# Altium schematic sheet Sitara_IO_Bank_45.SchDoc
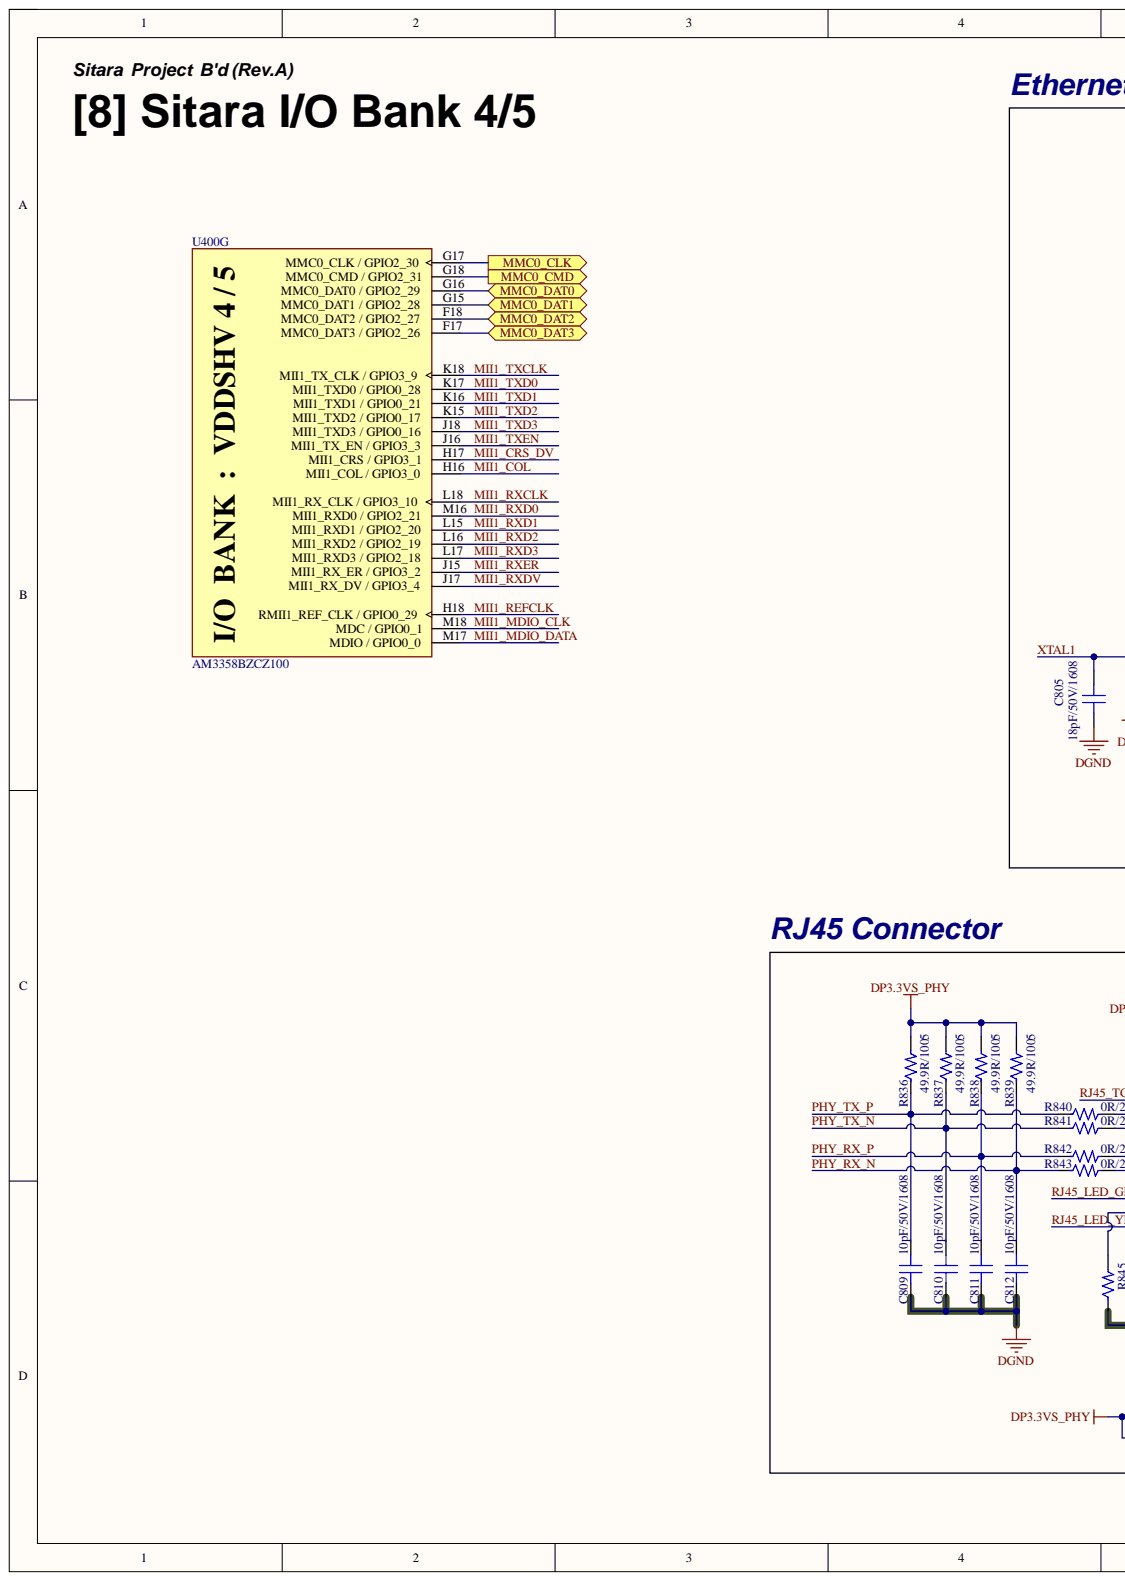
[diagram p0: Sitara_IO_Bank_45.SchDoc, left]
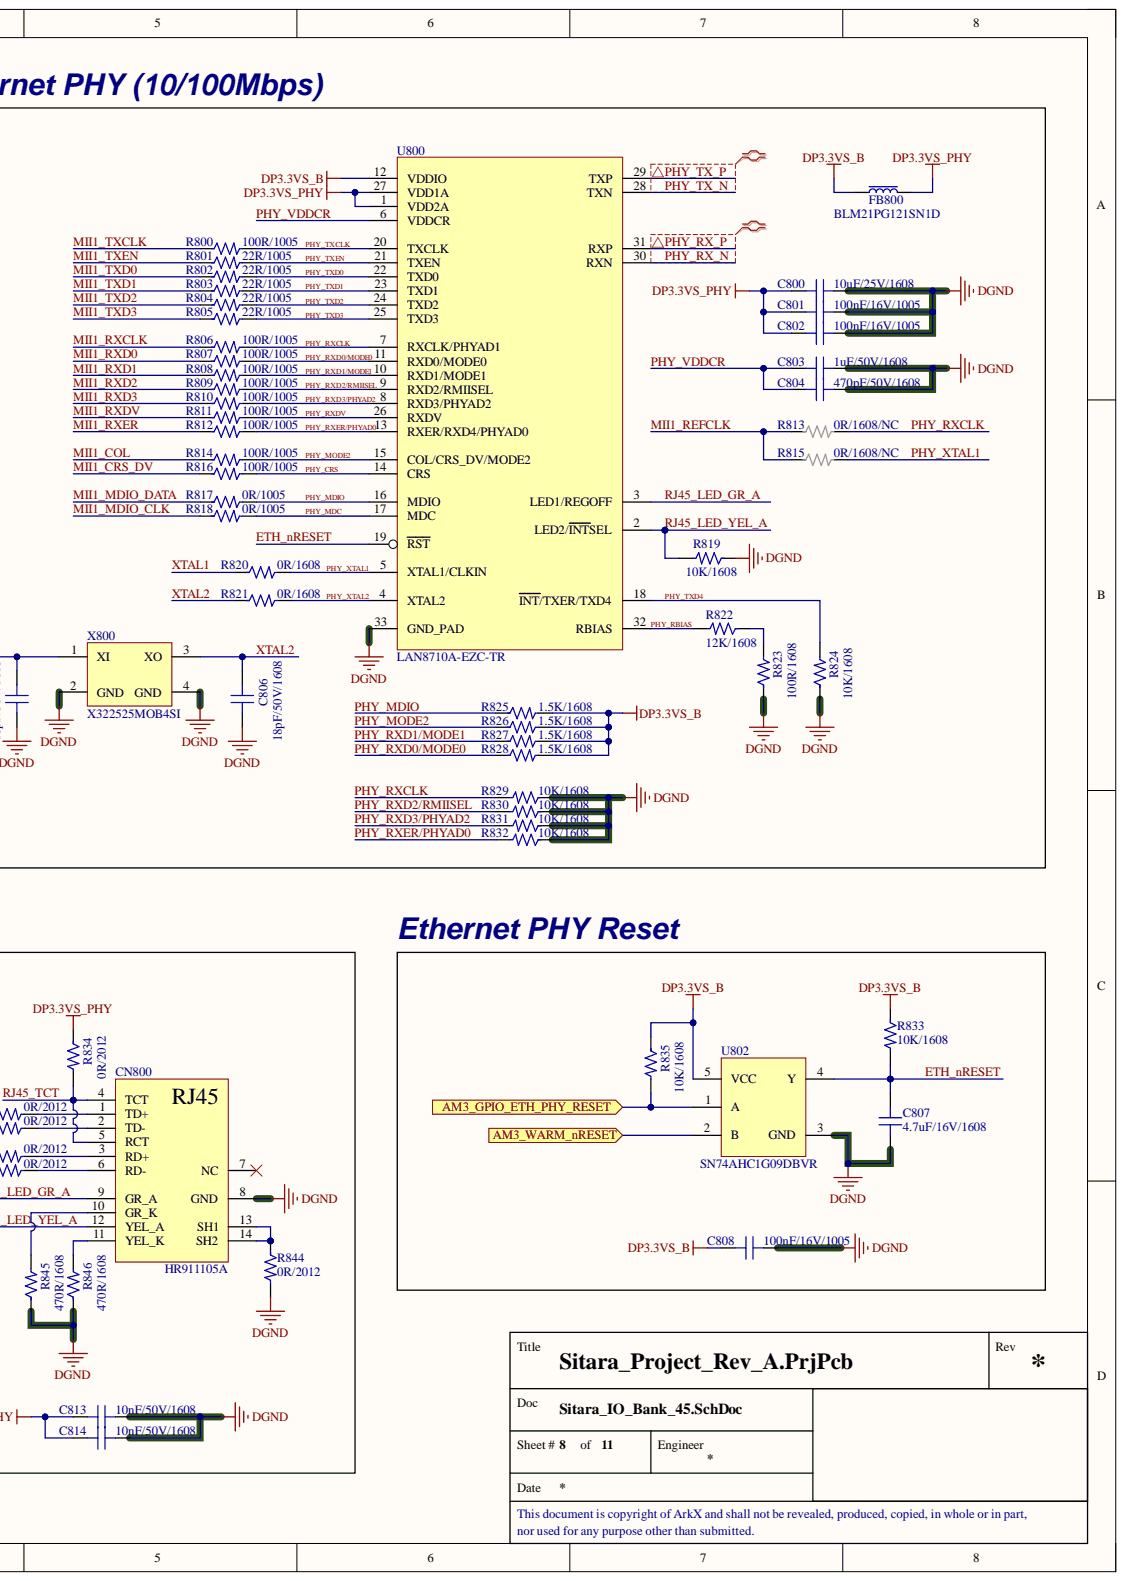
[diagram p1: Sitara_IO_Bank_45.SchDoc, right]

## Parts
C800  10uF/25V/1608  [CAP 1608 (0603_INCH)]
C801  100nF/16V/1005  [CAP 1005 (0402_INCH)]
C802  100nF/16V/1005  [CAP 1005 (0402_INCH)]
C803  1uF/50V/1608  [CAP 1608 (0603_INCH)]
C804  470pF/50V/1608  [CAP 1608 (0603_INCH)]
C805  18pF/50V/1608  [CAP 1608 (0603_INCH)]
C806  18pF/50V/1608  [CAP 1608 (0603_INCH)]
C807  4.7uF/16V/1608  [CAP 1608 (0603_INCH)]
C808  100nF/16V/1005  [CAP 1005 (0402_INCH)]
C809  10pF/50V/1608  [CAP 1608 (0603_INCH)]
C810  10pF/50V/1608  [CAP 1608 (0603_INCH)]
C811  10pF/50V/1608  [CAP 1608 (0603_INCH)]
C812  10pF/50V/1608  [CAP 1608 (0603_INCH)]
C813  10nF/50V/1608  [CAP 1608 (0603_INCH)]
C814  10nF/50V/1608  [CAP 1608 (0603_INCH)]
CN800  HR911105A  [CON_RJ45]
FB800  BLM21PG121SN1D  [FB 2012 (0805_inch)]
R800  100R/1005  [RES 1005 (0402_inch)]
R801  22R/1005  [RES 1005 (0402_inch)]
R802  22R/1005  [RES 1005 (0402_inch)]
R803  22R/1005  [RES 1005 (0402_inch)]
R804  22R/1005  [RES 1005 (0402_inch)]
R805  22R/1005  [RES 1005 (0402_inch)]
R806  100R/1005  [RES 1005 (0402_inch)]
R807  100R/1005  [RES 1005 (0402_inch)]
R808  100R/1005  [RES 1005 (0402_inch)]
R809  100R/1005  [RES 1005 (0402_inch)]
R810  100R/1005  [RES 1005 (0402_inch)]
R811  100R/1005  [RES 1005 (0402_inch)]
R812  100R/1005  [RES 1005 (0402_inch)]
R813  0R/1608/NC  [RES 1608 (0603_inch)/NC]
R814  100R/1005  [RES 1005 (0402_inch)]
R815  0R/1608/NC  [RES 1608 (0603_inch)/NC]
R816  100R/1005  [RES 1005 (0402_inch)]
R817  0R/1005  [RES 1005 (0402_inch)]
R818  0R/1005  [RES 1005 (0402_inch)]
R819  10K/1608  [RES 1608 (0603_inch)]
R820  0R/1608  [RES 1608 (0603_inch)]
R821  0R/1608  [RES 1608 (0603_inch)]
R822  12K/1608  [RES 1608 (0603_inch)]
R823  100R/1608  [RES 1608 (0603_inch)]
R824  10K/1608  [RES 1608 (0603_inch)]
R825  1.5K/1608  [RES 1608 (0603_inch)]
R826  1.5K/1608  [RES 1608 (0603_inch)]
R827  1.5K/1608  [RES 1608 (0603_inch)]
R828  1.5K/1608  [RES 1608 (0603_inch)]
R829  10K/1608  [RES 1608 (0603_inch)]
R830  10K/1608  [RES 1608 (0603_inch)]
R831  10K/1608  [RES 1608 (0603_inch)]
R832  10K/1608  [RES 1608 (0603_inch)]
R833  10K/1608  [RES 1608 (0603_inch)]
R834  0R/2012  [RES 2012 (0805_inch)]
R835  10K/1608  [RES 1608 (0603_inch)]
R836  49.9R/1005  [RES 1005 (0402_inch)]
R837  49.9R/1005  [RES 1005 (0402_inch)]
R838  49.9R/1005  [RES 1005 (0402_inch)]
R839  49.9R/1005  [RES 1005 (0402_inch)]
R840  0R/2012  [RES 2012 (0805_inch)]
R841  0R/2012  [RES 2012 (0805_inch)]
R842  0R/2012  [RES 2012 (0805_inch)]
R843  0R/2012  [RES 2012 (0805_inch)]
R844  0R/2012  [RES 2012 (0805_inch)]
R845  470R/1608  [RES 1608 (0603_inch)]
R846  470R/1608  [RES 1608 (0603_inch)]
U400  AM3358BZCZ100  [IC_SOC_AM3358BZCZ100]  (part G)
U800  LAN8710A-EZC-TR  [IC_QFN-32 (5x5)]
U802  SN74AHC1G09DBVR  [SOT-23-5 (TI DBV PACKAGE)]
X800  X322525MOB4SI  [OSC_SMD_3.2x2.5(YXC)]

## Ports
AM3_GPIO_ETH_PHY_RESET, AM3_WARM_nRESET, MMC0_CLK, MMC0_CMD, MMC0_DAT0, MMC0_DAT1, MMC0_DAT2, MMC0_DAT3

## Nets
DGND: C800.2 C801.2 C802.2 C803.2 C804.2 C805.1 C806.1 C807.1 C808.2 C809.1 C810.1 C811.1 C812.1 C813.2 C814.2 CN800.8(GND) R819.1 R823.1 R824.1 R829.1 R830.1 R831.1 R832.1 R844.2 R845.1 R846.1 U800.33(GND_PAD) U802.3(GND) X800.2(GND) X800.4(GND)
DP3.3VS_B: C808.1 FB800.1 R825.2 R826.2 R827.2 R828.2 R833.1 R835.1 U800.12(VDDIO) U802.5(VCC)
DP3.3VS_PHY: C800.1 C801.1 C802.1 C813.1 C814.1 FB800.2 R834.1 R836.2 R837.2 R838.2 R839.2 U800.1(VDD2A) U800.27(VDD1A)
AM3_GPIO_ETH_PHY_RESET: R835.2 U802.1(A) ; port AM3_GPIO_ETH_PHY_RESET
AM3_WARM_nRESET: U802.2(B) ; port AM3_WARM_nRESET
ETH_nRESET: C807.2 R833.2 U800.19(RST) U802.4(Y)
MII1_COL: R814.1 U400.H16(MII1_COL_/_GPIO3_0)
MII1_CRS_DV: R816.1 U400.H17(MII1_CRS_/_GPIO3_1)
MII1_MDIO_CLK: R818.1 U400.M18(MDC_/_GPIO0_1)
MII1_MDIO_DATA: R817.1 U400.M17(MDIO_/_GPIO0_0)
MII1_REFCLK: R813.1 R815.1 U400.H18(RMII1_REF_CLK_/_GPIO0_29)
MII1_RXCLK: R806.1 U400.L18(MII1_RX_CLK_/_GPIO3_10)
MII1_RXD0: R807.1 U400.M16(MII1_RXD0_/_GPIO2_21)
MII1_RXD1: R808.1 U400.L15(MII1_RXD1_/_GPIO2_20)
MII1_RXD2: R809.1 U400.L16(MII1_RXD2_/_GPIO2_19)
MII1_RXD3: R810.1 U400.L17(MII1_RXD3_/_GPIO2_18)
MII1_RXDV: R811.1 U400.J17(MII1_RX_DV_/_GPIO3_4)
MII1_RXER: R812.1 U400.J15(MII1_RX_ER_/_GPIO3_2)
MII1_TXCLK: R800.1 U400.K18(MII1_TX_CLK_/_GPIO3_9)
MII1_TXD0: R802.1 U400.K17(MII1_TXD0_/_GPIO0_28)
MII1_TXD1: R803.1 U400.K16(MII1_TXD1_/_GPIO0_21)
MII1_TXD2: R804.1 U400.K15(MII1_TXD2_/_GPIO0_17)
MII1_TXD3: R805.1 U400.J18(MII1_TXD3_/_GPIO0_16)
MII1_TXEN: R801.1 U400.J16(MII1_TX_EN_/_GPIO3_3)
MMC0_CLK: U400.G17(MMC0_CLK_/_GPIO2_30) ; port MMC0_CLK
MMC0_CMD: U400.G18(MMC0_CMD_/_GPIO2_31) ; port MMC0_CMD
MMC0_DAT0: U400.G16(MMC0_DAT0_/_GPIO2_29) ; port MMC0_DAT0
MMC0_DAT1: U400.G15(MMC0_DAT1_/_GPIO2_28) ; port MMC0_DAT1
MMC0_DAT2: U400.F18(MMC0_DAT2_/_GPIO2_27) ; port MMC0_DAT2
MMC0_DAT3: U400.F17(MMC0_DAT3_/_GPIO2_26) ; port MMC0_DAT3
PHY_CRS: R816.2 U800.14(CRS)
PHY_MDC: R818.2 U800.17(MDC)
PHY_MDIO: R817.2 R825.1 U800.16(MDIO)
PHY_MODE2: R814.2 R826.1 U800.15(COL/CRS_DV/MODE2)
PHY_RBIAS: R822.2 U800.32(RBIAS)
PHY_RX_N: C812.2 R839.1 R843.1 U800.30(RXN)
PHY_RX_P: C811.2 R838.1 R842.1 U800.31(RXP)
PHY_RXCLK: R806.2 R813.2 R829.2 U800.7(RXCLK/PHYAD1)
PHY_RXD0/MODE0: R807.2 R828.1 U800.11(RXD0/MODE0)
PHY_RXD1/MODE1: R808.2 R827.1 U800.10(RXD1/MODE1)
PHY_RXD2/RMIISEL: R809.2 R830.2 U800.9(RXD2/RMIISEL)
PHY_RXD3/PHYAD2: R810.2 R831.2 U800.8(RXD3/PHYAD2)
PHY_RXDV: R811.2 U800.26(RXDV)
PHY_RXER/PHYAD0: R812.2 R832.2 U800.13(RXER/RXD4/PHYAD0)
PHY_TX_N: C810.2 R837.1 R841.1 U800.28(TXN)
PHY_TX_P: C809.2 R836.1 R840.1 U800.29(TXP)
PHY_TXCLK: R800.2 U800.20(TXCLK)
PHY_TXD0: R802.2 U800.22(TXD0)
PHY_TXD1: R803.2 U800.23(TXD1)
PHY_TXD2: R804.2 U800.24(TXD2)
PHY_TXD3: R805.2 U800.25(TXD3)
PHY_TXD4: R824.2 U800.18(INT/TXER/TXD4)
PHY_TXEN: R801.2 U800.21(TXEN)
PHY_VDDCR: C803.1 C804.1 U800.6(VDDCR)
PHY_XTAL1: R815.2 R820.2 U800.5(XTAL1/CLKIN)
PHY_XTAL2: R821.2 U800.4(XTAL2)
RJ45_LED_GR_A: CN800.9(GR_A) U800.3(LED1/REGOFF)
RJ45_LED_YEL_A: CN800.12(YEL_A) R819.2 U800.2(LED2/INTSEL)
RJ45_TCT: CN800.4(TCT) CN800.5(RCT) R834.2
XTAL1: C805.2 R820.1 X800.1(XI)
XTAL2: C806.2 R821.1 X800.3(XO)
NetCN800_1: CN800.1(TD+) R840.2
NetCN800_2: CN800.2(TD-) R841.2
NetCN800_3: CN800.3(RD+) R842.2
NetCN800_6: CN800.6(RD-) R843.2
NetCN800_10: CN800.10(GR_K) R845.2
NetCN800_11: CN800.11(YEL_K) R846.2
NetCN800_13: CN800.13(SH1) CN800.14(SH2) R844.1
NetR822_1: R822.1 R823.2

Not connected: CN800 7(NC)

## Library data of the parts
C800 = CAP_10uF/25V/1608: Ceramic CAP_10uF/25V/1608; Component Kind: Standard; JLCPCB Part Type: Basic Part; LCSC Part #: C96446; Library Name: Arkx.IntLib
C801 C802 C808 = CAP_100nF/16V/1005: Ceramic CAP_100nF/16V/1005; Component Kind: Standard; JLCPCB Part Type: Basic Part; LCSC Part #: C1525; Library Name: Arkx.IntLib
C803 = CAP_1uF/50V/1608: Ceramic CAP_1uF/50V/1608; Component Kind: Standard; JLCPCB Part Type: Basic Part; LCSC Part #: C15849; Library Name: Arkx.IntLib
C804 = CAP_470pF/50V/1608: Ceramic CAP_470pF/50V/1608; Component Kind: Standard; JLCPCB Part Type: Basic Part; LCSC Part #: C1620; Library Name: Arkx.IntLib
C805 C806 = CAP_18pF/50V/1608: Ceramic CAP_18pF/50V/1608; Component Kind: Standard; JLCPCB Part Type: Basic Part; LCSC Part #: C1647; Library Name: Arkx.IntLib
C807 = CAP_4.7uF/16V/1608: Ceramic CAP_4.7uF/16V/1608; Component Kind: Standard; JLCPCB Part Type: Basic Part; LCSC Part #: C19666; Library Name: Arkx.IntLib
C809-C812 = CAP_10pF/50V/1608: Ceramic CAP_10pF/50V/1608; Component Kind: Standard; JLCPCB Part Type: Basic Part; LCSC Part #: C1634; Library Name: Arkx.IntLib
C813 C814 = CAP_10nF/50V/1608: Ceramic CAP_10nF/50V/1608; Component Kind: Standard; JLCPCB Part Type: Basic Part; LCSC Part #: C57112; Library Name: Arkx.IntLib
CN800 = CON_RJ45_HR911105A: RJ45Receptacle 1 WithLED Plugin Ethernet Connectors / Modular Connectors (RJ45 RJ11) ROHS; Component Kind: Standard; JLCPCB Part Type: Extended Part; LCSC Part #: C12074; Library Name: Arkx.IntLib
FB800 = FB_BLM21PG121SN1D: 3A 1 30mΩ 120Ω@100MHz ±25% 0805  Ferrite Beads ROHS; Component Kind: Standard; JLCPCB Part Type: Extended Part; LCSC Part #: C79382; Library Name: Arkx.IntLib
R800 R806-R812 R814 R816 = RES_100R/1005/62.5mW: Power Rating: 62.5mW; Precision: 1%; Value: 100R; Component Kind: Standard; Description: RES_100R/1005/62.5mW; JLCPCB Part Type: Basic Part; LCSC Part #: C25076; Size: 1005; Library Name: Arkx.IntLib
R801-R805 = RES_22R/1005/62.5mW: Power Rating: 62.5mW; Precision: 1%; Value: 22R; Component Kind: Standard; Description: RES_22R/1005/62.5mW; JLCPCB Part Type: Basic Part; LCSC Part #: C25092; Size: 1005; Library Name: Arkx.IntLib
R813 R815 = RES_NC_0R/1608/0.1W: 0R/1608/0.1W/NC; Power Rating: 0.1W; Precision: 1%; Value: 0R; Component Kind: Standard; Size: 1608; Library Name: Arkx.IntLib
R817 R818 = RES_0R/1005/62.5mW: Power Rating: 62.5mW; Precision: 1%; Value: 0R; Component Kind: Standard; Description: RES_0R/1005/62.5mW; JLCPCB Part Type: Basic Part; LCSC Part #: C17168; Size: 1005; Library Name: Arkx.IntLib
R819 R824 R829-R833 R835 = RES_10K/1608/0.1W: Power Rating: 0.1W; Precision: 1%; Value: 10K; Component Kind: Standard; Description: RES_10K/1608/0.1W; JLCPCB Part Type: Basic Part; LCSC Part #: C25804; Size: 1608; Library Name: Arkx.IntLib
R820 R821 = RES_0R/1608/0.1W: Power Rating: 0.1W; Precision: 1%; Value: 0R; Component Kind: Standard; Description: RES_0R/1608/0.1W; JLCPCB Part Type: Basic Part; LCSC Part #: C21189; Size: 1608; Library Name: Arkx.IntLib
R822 = RES_12K/1608/0.1W: Power Rating: 0.1W; Precision: 1%; Value: 12K; Component Kind: Standard; Description: RES_12K/1608/0.1W; JLCPCB Part Type: Basic Part; LCSC Part #: C22790; Size: 1608; Library Name: Arkx.IntLib
R823 = RES_100R/1608/0.1W: Power Rating: 0.1W; Precision: 1%; Value: 100R; Component Kind: Standard; Description: RES_100R/1608/0.1W; JLCPCB Part Type: Basic Part; LCSC Part #: C22775; Size: 1608; Library Name: Arkx.IntLib
R825-R828 = RES_1.5K/1608/0.1W: Power Rating: 0.1W; Precision: 1%; Value: 1.5K; Component Kind: Standard; Description: RES_1.5K/1608/0.1W; JLCPCB Part Type: Basic Part; LCSC Part #: C22843; Size: 1608; Library Name: Arkx.IntLib
R834 R840-R844 = RES_0R/2012/0.125W: Power Rating: 0.125W; Precision: 1%; Value: 0R; Component Kind: Standard; Description: RES_0R/2012/0.125W; JLCPCB Part Type: Basic Part; LCSC Part #: C17477; Size: 2012; Library Name: Arkx.IntLib
R836-R839 = RES_49.9R/1005/62.5mW: Power Rating: 62.5mW; Precision: 1%; Value: 49.9R; Component Kind: Standard; Description: RES_49.9R/1005/62.5mW; JLCPCB Part Type: Basic Part; LCSC Part #: C25120; Size: 1005; Library Name: Arkx.IntLib
R845 R846 = RES_470R/1608/0.1W: Power Rating: 0.1W; Precision: 1%; Value: 470R; Component Kind: Standard; Description: RES_470R/1608/0.1W; JLCPCB Part Type: Basic Part; LCSC Part #: C23179; Size: 1608; Library Name: Arkx.IntLib
U400 = IC_SOC_AM3358BZCZ100: ARM® Cortex®-A8 Microprocessor IC Sitara™ 1 Core, 32-Bit 1.0GHz 324-NFBGA (15x15); Component Kind: Standard; JLCPCB Part Type: Extended Part; LCSC Part #: C73932; Library Name: Arkx.IntLib
U800 = IC_ETH_LAN8710A-EZC-TR: 4/4 Transceiver Full MII, RMII 32-QFN (5x5); Component Kind: Standard; JLCPCB Part Type: Extended Part; LCSC Part #: C46185; Library Name: Arkx.IntLib
U802 = IC_AND_SN74AHC1G09DBVR: AND Gate IC 1 Channel Open Drain SOT-23-5; Component Kind: Standard; JLCPCB Part Type: Extended Part; LCSC Part #: C840099; Library Name: Arkx.IntLib
X800 = XTAL_25MHz_X322525MOB4SI: 25MHz Surface Mount Crystal 12pF ±10ppm ±20ppm SMD3225-4P Crystals ROHS; Component Kind: Standard; JLCPCB Part Type: Basic Part; LCSC Part #: C9006; Library Name: Arkx.IntLib
